# Altium schematic sheet Power.SchDoc(Power)
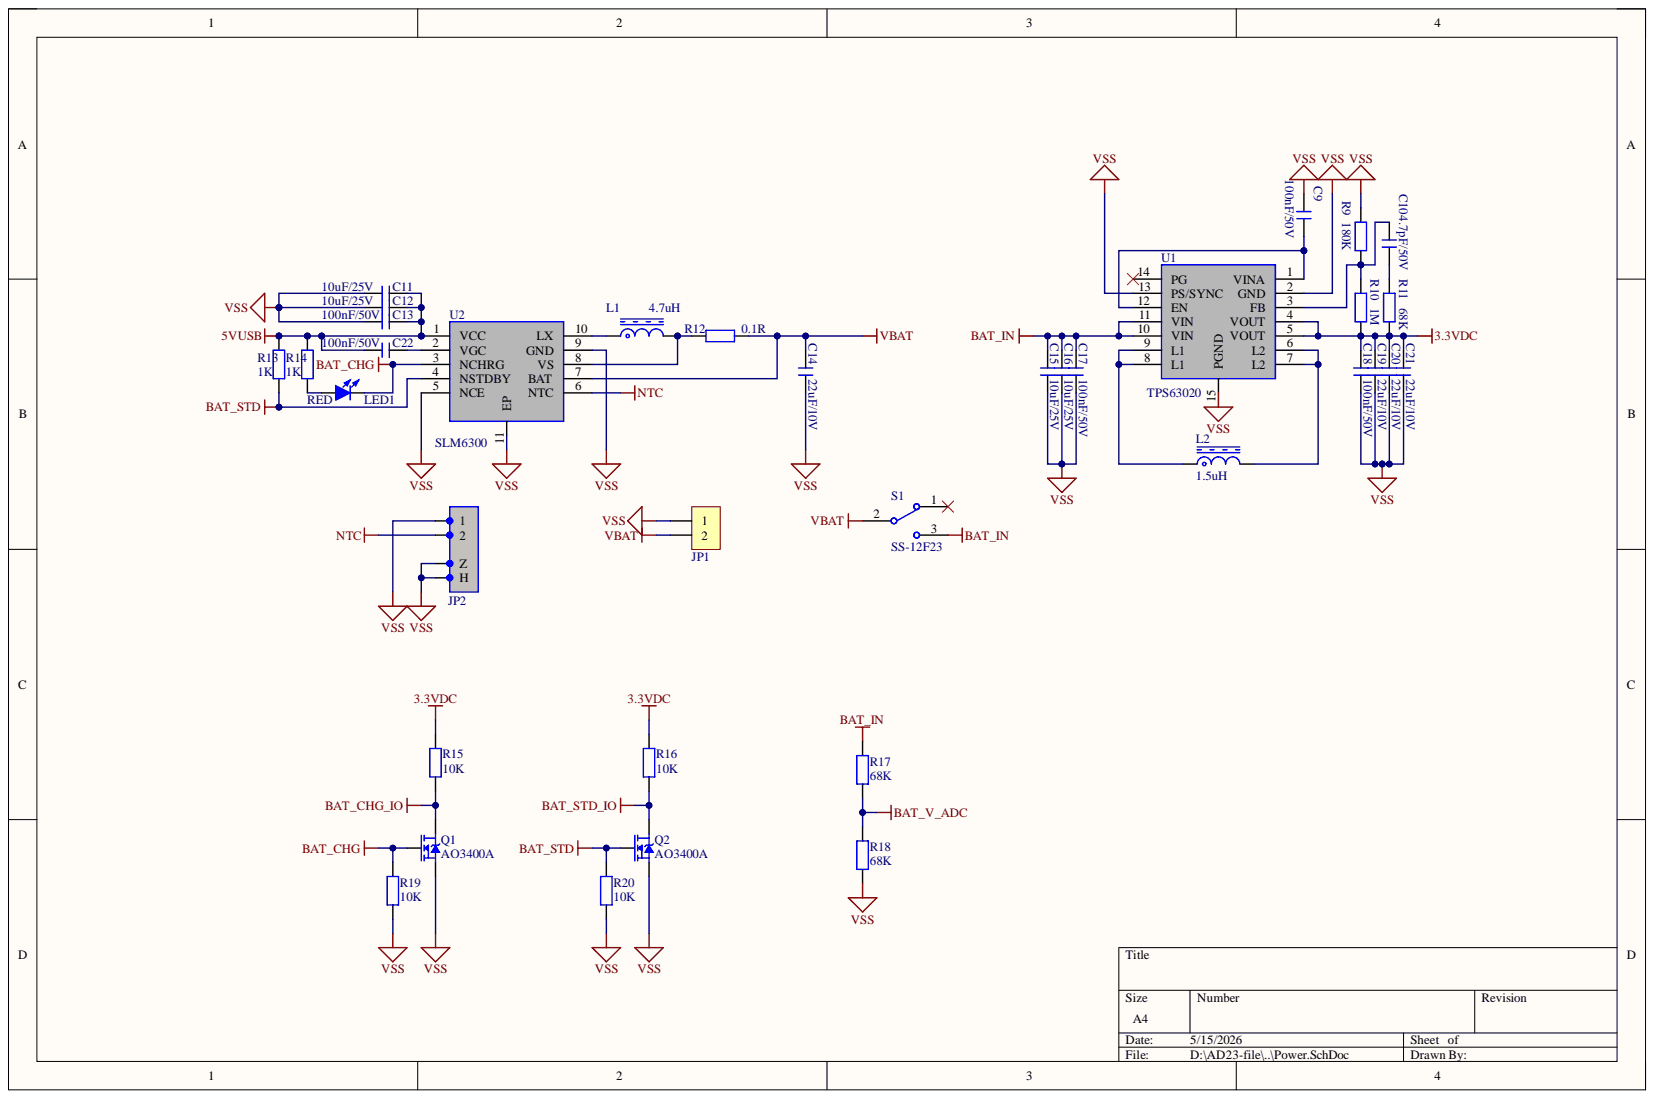
[diagram p0: Power.SchDoc]

## Parts
C9  100nF/50V  [C 0603_L]
C10  4.7pF/50V  [C 0603_L]
C11  10uF/25V  [C 0603_L]
C12  10uF/25V  [C 0603_L]
C13  100nF/50V  [C 0603_L]
C14  22uF/10V  [C 0603_L]
C15  10uF/25V  [C 0603_L]
C16  10uF/25V  [C 0603_L]
C17  100nF/50V  [C 0603_L]
C18  100nF/50V  [C 0603_L]
C19  22uF/10V  [C 0603_L]
C20  22uF/10V  [C 0603_L]
C21  22uF/10V  [C 0603_L]
C22  100nF/50V  [C 0603_L]
JP1  HDR-1X2  [PH2.0-WS-2P]  (value not drawn)
JP2  HDR-1X2  [MX 1.25-WS-2P]  (value not drawn)
L1  4.7uH  [CKST0402]
L2  1.5uH  [CKST0402]
LED1  RED  [LED 0603G]
Q1  AO3400A  [SOT23-3L]
Q2  AO3400A  [SOT23-3L]
R9  180K  [R 0603_L]
R10  1M  [R 0603_L]
R11  68K  [R 0603_L]
R12  0.1R  [R 0805_L]
R13  1K  [R 0603_L]
R14  1K  [R 0603_L]
R15  10K  [R 0603_L]
R16  10K  [R 0603_L]
R17  68K  [R 0603_L]
R18  68K  [R 0603_L]
R19  10K  [R 0603_L]
R20  10K  [R 0603_L]
S1  SS-12F23  [SS-12F23]
U1  TPS63020  [VSON-14]
U2  SLM6300  [DFN3*3-10]

## Nets
3.3VDC: C18.2 C19.2 C20.2 C21.2 R10.1 R11.1 R15.1 R16.1 U1.4(VOUT) U1.5(VOUT)
5VUSB: C11.2 C12.2 C13.2 C22.1 R13.2 R14.2 U2.1(VCC)
BAT_CHG: LED1.2 Q1.1 R19.1 U2.3(NCHRG)
BAT_CHG_IO: Q1.2 R15.2
BAT_IN: C15.2 C16.2 C17.2 R17.2 S1.3 U1.10(VIN) U1.11(VIN)
BAT_STD: Q2.1 R13.1 R20.1 U2.4(NSTDBY)
BAT_STD_IO: Q2.2 R16.2
BAT_V_ADC: R17.1 R18.2
NTC: JP2.2 U2.6(NTC)
VBAT: C14.2 JP1.2 R12.2 S1.2 U2.7(BAT)
VSS: C9.1 C11.1 C12.1 C13.1 C14.1 C15.1 C16.1 C17.1 C18.1 C19.1 C20.1 C21.1 JP1.1 JP2.0(Z) JP2.1 JP2.100(H) Q1.3 Q2.3 R9.2 R18.1 R19.2 R20.2 U1.2(GND) U1.13(PS/SYNC) U1.15(PGND) U2.5(NCE) U2.9(GND) U2.11(EP)
NetC9_2: C9.2 U1.1(VINA) U1.12(EN)
NetC10_1: C10.1 R9.1 R10.2 U1.3(FB)
NetC10_2: C10.2 R11.2
NetC22_2: C22.2 U2.2(VGC)
NetL1_1: L1.1 U2.10(LX)
NetL1_2: L1.2 R12.1 U2.8(VS)
NetL2_1: L2.1 U1.8(L1) U1.9(L1)
NetL2_2: L2.2 U1.6(L2) U1.7(L2)
NetLED1_1: LED1.1 R14.1

Not connected: S1 1; U1 14(PG)

## Library data of the parts
C9-C22 = C: 无极性贴片电容; 版权归属: 源创客; BOM名称: 无极性贴片电容; Component Kind: Standard; Library Name: 3.2-电容.SchLib; 学习资源: www.ooocke.com
JP1 JP2 = Header 2: 2P接插件; 版权归属: 源创客; BOM名称: 2P接插件; Component Kind: Standard; 学习资源: www.ooocke.com
  JP1: Library Name: 14.5-接插件-脚距2.0.SchLib
  JP2: Library Name: 14.3-接插件-脚距1.25.SchLib
L1 L2 = L-HP: 一体成型电感; BOM名称: 功率屏蔽电感; 版权归属: 源创客; Component Kind: Standard; Library Name: 3.3-电感.SchLib; 学习资源: www.ooocke.com
LED1 = LED-SMD: 贴片LED; 版权归属: 源创客; BOM名称: 贴片LED; Component Kind: Standard; Library Name: 7.1-LED.SchLib; 学习资源: www.ooocke.com
Q1 Q2 = NMOS_SS: 贴片信号N沟道场效应管; 版权归属: 源创客; BOM名称: N沟道场效应管; Component Kind: Standard; Library Name: 5.2-场效应管.SchLib; 学习资源: www.ooocke.com
R9-R20 = R: 贴片电阻; 版权归属: 源创客; BOM名称: 贴片电阻; Component Kind: Standard; Library Name: 3.1-电阻.SchLib; 学习资源: www.ooocke.com
S1 = SSW-1P: 1路拨动开关; BOM名称: 波动开关; 版权归属: 源创客; Component Kind: Standard; Library Name: 6.2-开关.SchLib; 学习资源: www.ooocke.com
U1 = TPS63020: 具有 4A 开关的高效率单电感器降压/升压转换器; Component Kind: Standard; Library Name: 1.3-电源芯片.SchLib
U2 = SLM6300: 高耐压 2.5A 同步降压锂电池充电器; Component Kind: Standard; Library Name: 1.3-电源芯片.SchLib
